# Altium schematic sheet multi_ranger_deck.SchDoc(multi_ranger_deck)
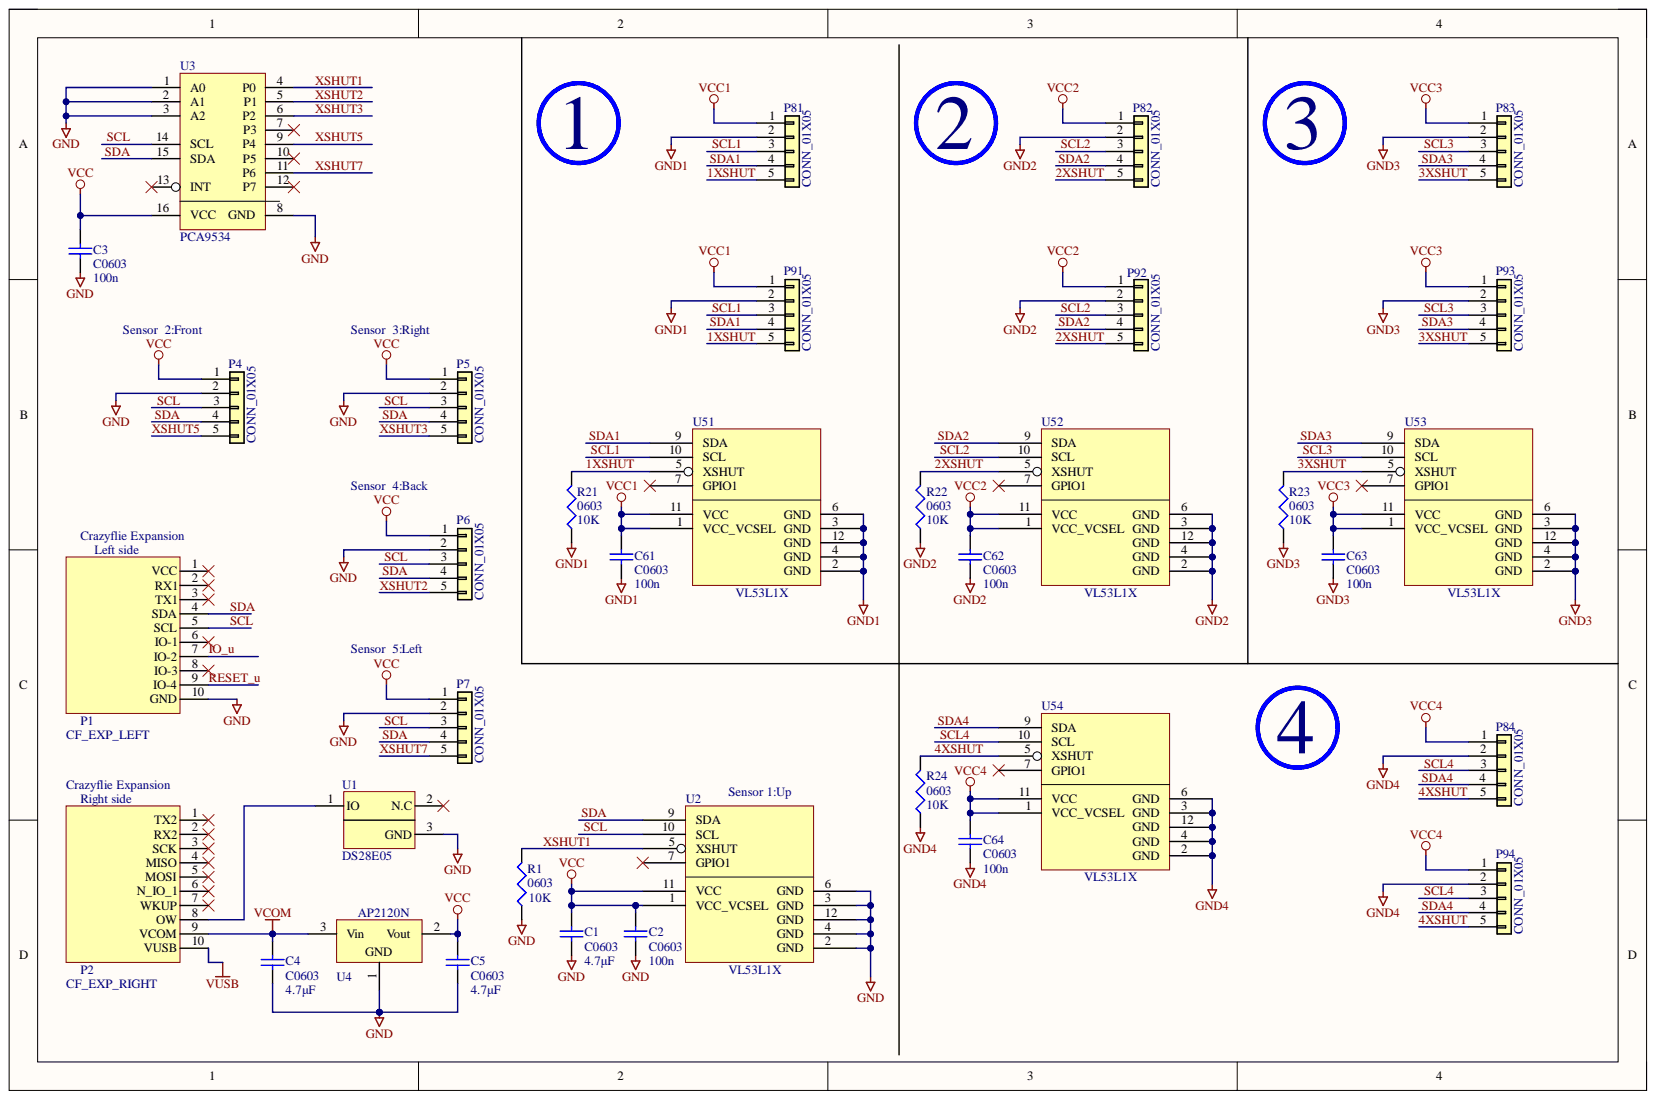
[diagram p0: multi_ranger_deck.SchDoc]

## Parts
C1  C0603  value 4.7μF  [C0603]
C2  C0603  value 100n  [C0603]
C3  C0603  value 100n  [C0603]
C4  C0603  value 4.7μF  [C0603]
C5  C0603  value 4.7μF  [C0603]
C61  C0603  value 100n  [C0603]
C62  C0603  value 100n  [C0603]
C63  C0603  value 100n  [C0603]
C64  C0603  value 100n  [C0603]
P1  CF_EXP_LEFT  [HDR1X10_2]
P2  CF_EXP_RIGHT  [PCBComponent_1]
P4  Sensor  2:Front  [PCBComponent_1]
P5  Sensor  3:Right  [PCBComponent_1]
P6  Sensor  4:Back  [PCBComponent_1]
P7  Sensor  5:Left  [Model Name]
P81  Sensor  2:Front  [PCBComponent_1]
P82  Sensor  2:Front  [PCBComponent_1]
P83  Sensor  2:Front  [PCBComponent_1]
P84  Sensor  2:Front  [PCBComponent_1]
P91  Sensor  2:Front  [PCBComponent_1]
P92  Sensor  2:Front  [PCBComponent_1]
P93  Sensor  2:Front  [PCBComponent_1]
P94  Sensor  2:Front  [PCBComponent_1]
R1  0603  value 10K  [R0603]
R21  0603  value 10K  [R0603]
R22  0603  value 10K  [R0603]
R23  0603  value 10K  [R0603]
R24  0603  value 10K  [R0603]
U1  DS28E05  [SOT23-3_N]
U2  VL53L1X  [VL53L1X]
U3  PCA9534  [PCBComponent_1]
U4  AP2120N  [PCBComponent_1]
U51  VL53L1X  [VL53L1X]
U52  VL53L1X  [VL53L1X]
U53  VL53L1X  [VL53L1X]
U54  VL53L1X  [VL53L1X]

## Nets
GND: C1.1 C2.1 C3.1 C4.1 C5.1 P1.10(GND) P4.2 P5.2 P6.2 P7.2 R1.1 U1.3 U2.2(GND) U2.3(GND) U2.4(GND) U2.6(GND) U2.12(GND) U3.1(A0) U3.2(A1) U3.3(A2) U3.8(GND) U4.1
GND1: C61.1 P81.2 P91.2 R21.1 U51.2(GND) U51.3(GND) U51.4(GND) U51.6(GND) U51.12(GND)
GND2: C62.1 P82.2 P92.2 R22.1 U52.2(GND) U52.3(GND) U52.4(GND) U52.6(GND) U52.12(GND)
GND3: C63.1 P83.2 P93.2 R23.1 U53.2(GND) U53.3(GND) U53.4(GND) U53.6(GND) U53.12(GND)
GND4: C64.1 P84.2 P94.2 R24.1 U54.2(GND) U54.3(GND) U54.4(GND) U54.6(GND) U54.12(GND)
VCC: C1.2 C2.2 C3.2 C5.2 P4.1 P5.1 P6.1 P7.1 U2.1(VCC_VCSEL) U2.11(VCC) U3.16(VCC) U4.2
VCC1: C61.2 P81.1 P91.1 U51.1(VCC_VCSEL) U51.11(VCC)
VCC2: C62.2 P82.1 P92.1 U52.1(VCC_VCSEL) U52.11(VCC)
VCC3: C63.2 P83.1 P93.1 U53.1(VCC_VCSEL) U53.11(VCC)
VCC4: C64.2 P84.1 P94.1 U54.1(VCC_VCSEL) U54.11(VCC)
VCOM: C4.2 P2.9(VCOM) U4.3
VUSB: P2.10(VUSB)
1XSHUT: P81.5 P91.5 R21.2 U51.5(XSHUT)
2XSHUT: P82.5 P92.5 R22.2 U52.5(XSHUT)
3XSHUT: P83.5 P93.5 R23.2 U53.5(XSHUT)
4XSHUT: P84.5 P94.5 R24.2 U54.5(XSHUT)
~{RESET_u}: P1.9(IO-4)
IO_u: P1.7(IO-2)
SCL: P1.5(SCL) P4.3 P5.3 P6.3 P7.3 U2.10(SCL) U3.14(SCL)
SCL1: P81.3 P91.3 U51.10(SCL)
SCL2: P82.3 P92.3 U52.10(SCL)
SCL3: P83.3 P93.3 U53.10(SCL)
SCL4: P84.3 P94.3 U54.10(SCL)
SDA: P1.4(SDA) P4.4 P5.4 P6.4 P7.4 U2.9(SDA) U3.15(SDA)
SDA1: P81.4 P91.4 U51.9(SDA)
SDA2: P82.4 P92.4 U52.9(SDA)
SDA3: P83.4 P93.4 U53.9(SDA)
SDA4: P84.4 P94.4 U54.9(SDA)
XSHUT1: R1.2 U2.5(XSHUT) U3.4(P0)
XSHUT2: P6.5 U3.5(P1)
XSHUT3: P5.5 U3.6(P2)
XSHUT5: P4.5 U3.9(P4)
XSHUT7: P7.5 U3.11(P6)
NetP2_8: P2.8(OW) U1.1

Not connected: P1 1(VCC) 2(RX1) 3(TX1) 6(IO-1) 8(IO-3); P2 1(TX2) 2(RX2) 3(SCK) 4(MISO) 5(MOSI) 6(N_IO_1) 7(WKUP); U1 2; U2 7(GPIO1); U3 7(P3) 10(P5) 12(P7) 13(INT); U51 7(GPIO1); U52 7(GPIO1); U53 7(GPIO1); U54 7(GPIO1)

## Library data of the parts
C1-C5 C61-C64 = Cap: Capacitor; Component Kind: Standard; LatestRevisionDate: 29-May-2009; LatestRevisionNote: IPC-7351 Footprint Added.; Library Name: General.SchLib; PackageDescription: Chip Capacitor; PackageReference: C1206; Published: 8-Jun-2000; Publisher: Altium Limited
P1 P2 P4-P7 P81-P84 P91-P94 U1-U3 U51-U54 = Component_1: Component Kind: Standard
  P1 P2: Library Name: P1.SchLib
  P4-P7 P81-P84 P91-P94: Library Name: P-5.SchLib
  U1: ComponentLink1Description: New link; Library Name: DS28E05.SchLib
  U2 U51-U54: Library Name: VL53L1X.SchLib
  U3: Library Name: PCA9534.SchLib
R1 R21-R24 = Res: Resistor; Component Kind: Standard; LatestRevisionDate: 29-May-2009; LatestRevisionNote: IPC-7351 Footprint Added.; Library Name: General.SchLib; PackageDescription: Chip Resistor; PackageReference: J1-0603; Published: 8-Jun-2000; Publisher: Altium Limited
U4 = Volt Reg: Voltage Regulator; Component Kind: Standard; LatestRevisionDate: 29-May-2009; LatestRevisionNote: IPC-7351 Footprint Added.; Library Name: Miscellaneous Devices.IntLib; PackageDescription: 3-Pin Transistor Outline Package 2.54 mm Pitch, 15.1 mm Lead Span; PackageReference: D2PAK; Published: 24-Mar-1999; Publisher: Altium Limited
